# Altium schematic sheet jsk_mbzirc_micro1.SchDoc(jsk_mbzirc_micro1)
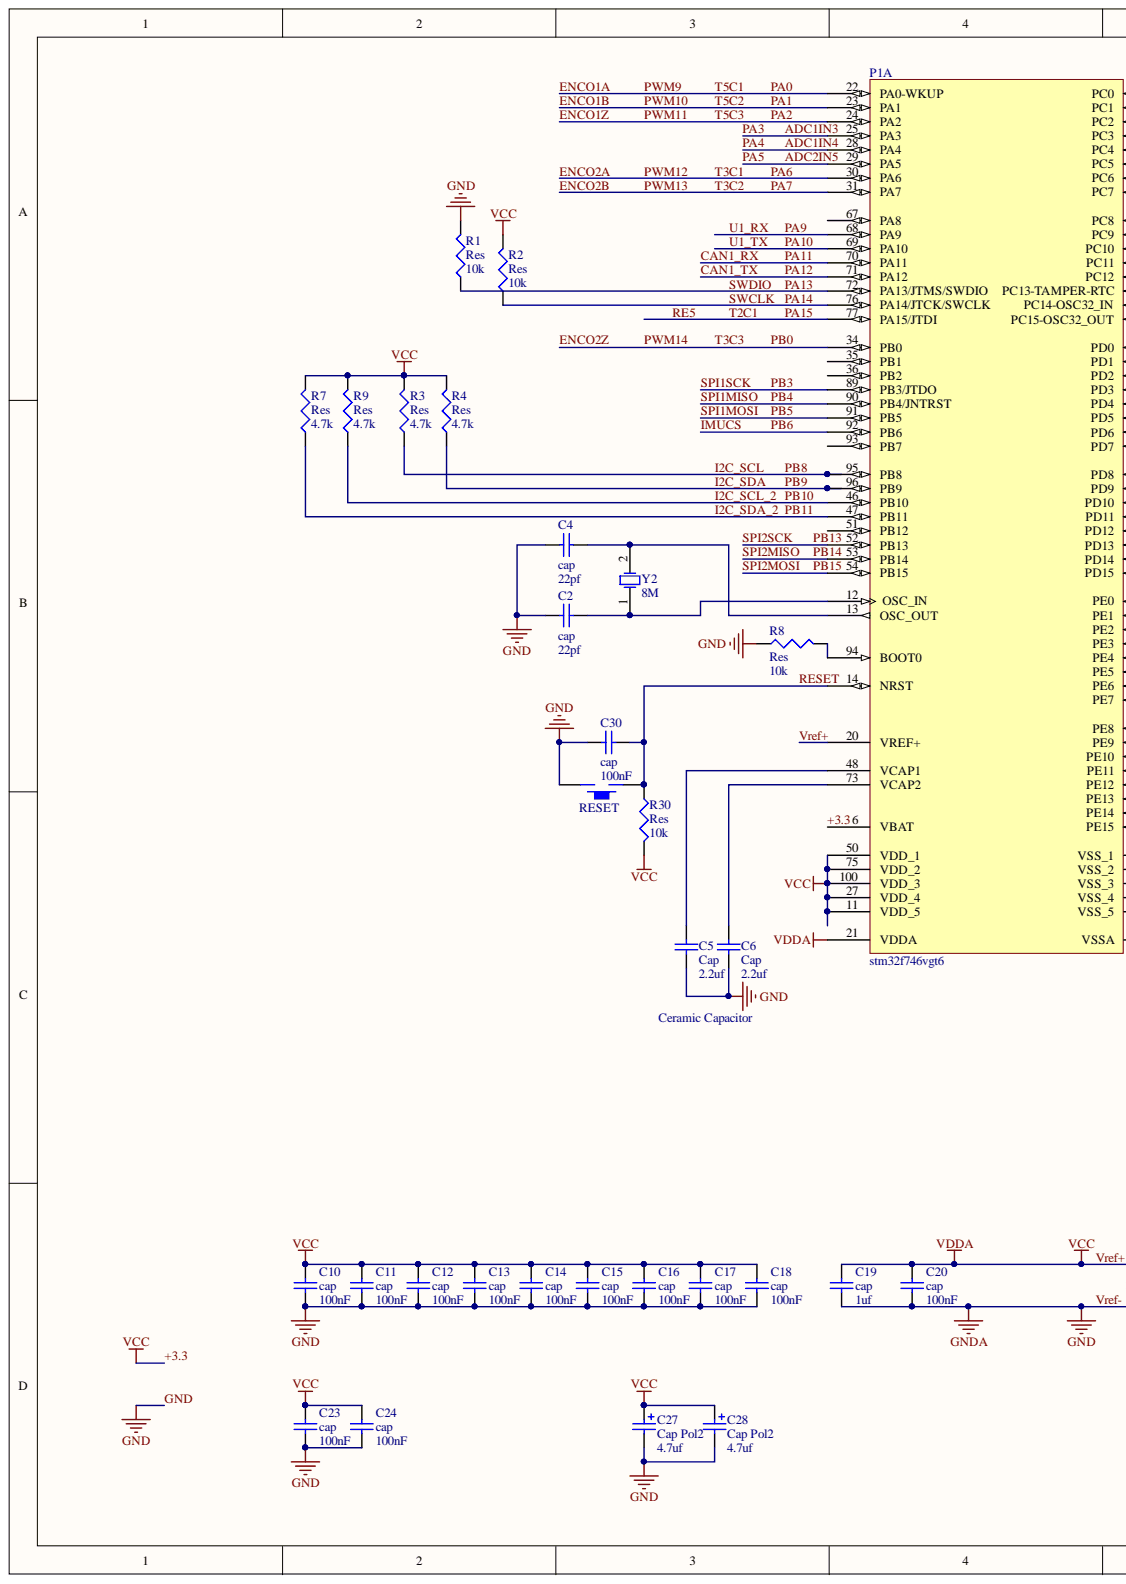
[diagram p0: jsk_mbzirc_micro1.SchDoc, left]
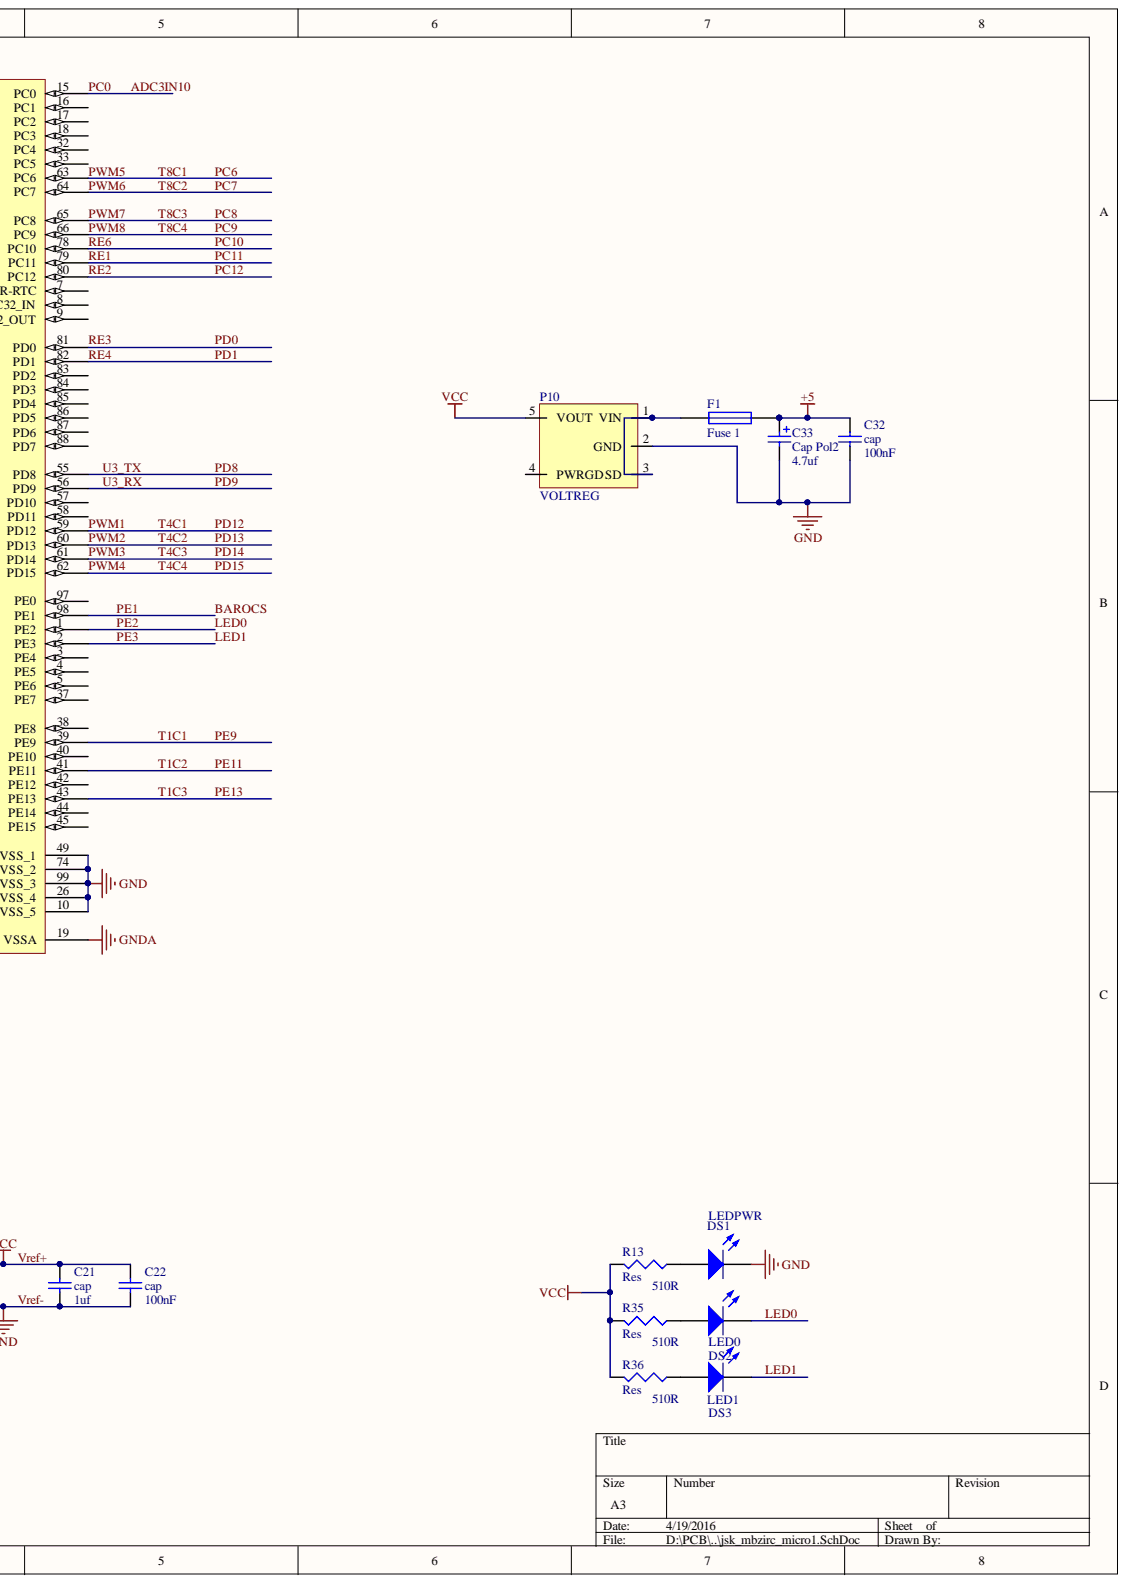
[diagram p1: jsk_mbzirc_micro1.SchDoc, right]

## Parts
C2  cap  value 22pf  [J1-0603]
C4  cap  value 22pf  [J1-0603]
C5  Cap  value 2.2uf  [0805]
C6  Cap  value 2.2uf  [0805]
C10  cap  value 100nF  [J1-0603]
C11  cap  value 100nF  [J1-0603]
C12  cap  value 100nF  [J1-0603]
C13  cap  value 100nF  [J1-0603]
C14  cap  value 100nF  [J1-0603]
C15  cap  value 100nF  [J1-0603]
C16  cap  value 100nF  [J1-0603]
C17  cap  value 100nF  [J1-0603]
C18  cap  value 100nF  [J1-0603]
C19  cap  value 1uf  [J1-0603]
C20  cap  value 100nF  [J1-0603]
C21  cap  value 1uf  [J1-0603]
C22  cap  value 100nF  [J1-0603]
C23  cap  value 100nF  [J1-0603]
C24  cap  value 100nF  [J1-0603]
C27  Cap Pol2  value 4.7uf  [C1206]
C28  Cap Pol2  value 4.7uf  [C1206]
C30  cap  value 100nF  [J1-0603]
C32  cap  value 100nF  [J1-0603]
C33  Cap Pol2  value 4.7uf  [C1206]
DS1  LEDPWR  [1206]
DS2  LED0  [SMDLED]
DS3  LED1  [SMDLED]
F1  Fuse 1  [J1-0603]
P1A  stm32f746vgt6
P10  VOLTREG  [SOT-23]
R1  Res  value 10k  [J1-0603]
R2  Res  value 10k  [J1-0603]
R3  Res  value 4.7k  [J1-0603]
R4  Res  value 4.7k  [J1-0603]
R7  Res  value 4.7k  [J1-0603]
R8  Res  value 10k  [J1-0603]
R9  Res  value 4.7k  [J1-0603]
R13  Res  value 510R  [J1-0603]
R30  Res  value 10k  [J1-0603]
R35  Res  value 510R  [J1-0603]
R36  Res  value 510R  [J1-0603]
RESET  KEY_M  [TSWITCH]  (value not drawn)
Y2  8M  [XTALSMD8]

## Nets
+5: C32.2 C33.1 F1.2
+3.3: C10.2 C11.2 C12.2 C13.2 C14.2 C15.2 C16.2 C17.2 C18.2 C19.2 C20.2 C21.2 C22.2 C23.2 C24.2 C27.1 C28.1 P1A.6(VBAT) P1A.11(VDD_5) P1A.20(VREF+) P1A.21(VDDA) P1A.27(VDD_4) P1A.50(VDD_1) P1A.75(VDD_2) P1A.100(VDD_3) P10.5(VOUT) R2.2 R3.2 R4.2 R7.2 R9.2 R13.2 R30.2 R35.2 R36.2
ADC1IN3: P1A.25(PA3)
ADC1IN4: P1A.28(PA4)
ADC2IN5: P1A.29(PA5)
ADC3IN10: P1A.15(PC0)
BAROCS: P1A.98(PE1)
CAN1_RX: P1A.70(PA11)
CAN1_TX: P1A.71(PA12)
ENCO1A: P1A.22(PA0-WKUP)
ENCO1B: P1A.23(PA1)
ENCO1Z: P1A.24(PA2)
ENCO2A: P1A.30(PA6)
ENCO2B: P1A.31(PA7)
ENCO2Z: P1A.34(PB0)
GND: C2.1 C4.1 C5.1 C6.1 C10.1 C11.1 C12.1 C13.1 C14.1 C15.1 C16.1 C17.1 C18.1 C19.1 C20.1 C21.1 C22.1 C23.1 C24.1 C27.2 C28.2 C30.1 C32.1 C33.2 DS1.2 P1A.10(VSS_5) P1A.19(VSSA) P1A.26(VSS_4) P1A.49(VSS_1) P1A.74(VSS_2) P1A.99(VSS_3) P10.2(GND) R1.2 R8.1 RESET.2
I2C_SCL: P1A.95(PB8) R3.1
I2C_SCL_2: P1A.46(PB10) R9.1
I2C_SDA: P1A.96(PB9) R4.1
I2C_SDA_2: P1A.47(PB11) R7.1
IMUCS: P1A.92(PB6)
LED0: DS2.2 P1A.1(PE2)
LED1: DS3.2 P1A.2(PE3)
PA9: P1A.68(PA9)
PA10: P1A.69(PA10)
PA13: P1A.72(PA13/JTMS/SWDIO) R1.1
PA14: P1A.76(PA14/JTCK/SWCLK) R2.1
PA15: P1A.77(PA15/JTDI)
PB3: P1A.89(PB3/JTDO)
PB4: P1A.90(PB4/JNTRST)
PB5: P1A.91(PB5)
PB13: P1A.52(PB13)
PB14: P1A.53(PB14)
PB15: P1A.54(PB15)
PC6: P1A.63(PC6)
PC7: P1A.64(PC7)
PC8: P1A.65(PC8)
PC9: P1A.66(PC9)
PC10: P1A.78(PC10)
PC11: P1A.79(PC11)
PC12: P1A.80(PC12)
PD0: P1A.81(PD0)
PD1: P1A.82(PD1)
PD8: P1A.55(PD8)
PD9: P1A.56(PD9)
PD12: P1A.59(PD12)
PD13: P1A.60(PD13)
PD14: P1A.61(PD14)
PD15: P1A.62(PD15)
PE9: P1A.39(PE9)
PE11: P1A.41(PE11)
PE13: P1A.43(PE13)
RESET: C30.2 P1A.14(NRST) R30.1 RESET.1
NetC2_2: C2.2 P1A.12(OSC_IN) Y2.1
NetC4_2: C4.2 P1A.13(OSC_OUT) Y2.2
NetC5_2: C5.2 P1A.48(VCAP1)
NetC6_2: C6.2 P1A.73(VCAP2)
NetDS1_1: DS1.1 R13.1
NetDS2_1: DS2.1 R35.1
NetDS3_1: DS3.1 R36.1
NetF1_1: F1.1 P10.1(VIN) P10.3(SD)
NetP1A_94: P1A.94(BOOT0) R8.2

Not connected: P1A 3(PE4) 4(PE5) 5(PE6) 7(PC13-TAMPER-RTC) 8(PC14-OSC32_IN) 9(PC15-OSC32_OUT) 16(PC1) 17(PC2) 18(PC3) 32(PC4) 33(PC5) 35(PB1) 36(PB2) 37(PE7) 38(PE8) 40(PE10) 42(PE12) 44(PE14) 45(PE15) 51(PB12) 57(PD10) 58(PD11) 67(PA8) 83(PD2) 84(PD3) 85(PD4) 86(PD5) 87(PD6) 88(PD7) 93(PB7) 97(PE0); P10 4(PWRGD)

## Library data of the parts
C2 C10-C24 C32 = Cap Semi: Capacitor (Semiconductor SIM Model); Component Kind: Standard; LatestRevisionDate: 29-May-2009; LatestRevisionNote: IPC-7351 Footprint Added.; Library Name: Miscellaneous Devices.IntLib; PackageDescription: Chip Capacitor; PackageReference: C1206; Published: 8-Jun-2000; Signal Integrity: Cap Semi; Simulation: CAP; Publisher: Altium Limited
C4 = Cap Semi: Capacitor (Semiconductor SIM Model); Value: 22pf; Component Kind: Standard; LatestRevisionDate: 29-May-2009; LatestRevisionNote: IPC-7351 Footprint Added.; Library Name: Miscellaneous Devices.IntLib; PackageDescription: Chip Capacitor; PackageReference: C1206; Published: 8-Jun-2000; Signal Integrity: Cap Semi; Simulation: CAP; Publisher: Altium Limited
C5 C6 = Cap: Capacitor; Component Kind: Standard; LatestRevisionDate: 17-Jul-2002; LatestRevisionNote: Re-released for DXP Platform.; Library Name: Miscellaneous Devices.IntLib; PackageDescription: Radial Cap, Thru-Hole; 2 Leads; 0.3 in Pin Spacing; PackageReference: RAD-0.3; Published: 8-Jun-2000; Signal Integrity: Cap; Simulation: CAP; Publisher: Altium Limited
C27 C28 C33 = Cap Pol2: Polarized Capacitor (Axial); Component Kind: Standard; LatestRevisionDate: 17-Jul-2002; LatestRevisionNote: Re-released for DXP Platform.; Library Name: Miscellaneous Devices.IntLib; PackageDescription: Polarized Capacitor; 2 Leads; PackageReference: POLAR0.8; Published: 8-Jun-2000; Signal Integrity: Cap Pol; Simulation: CAP; Publisher: Altium Limited
C30 = Cap Semi: Capacitor (Semiconductor SIM Model); Value: 100nF; Component Kind: Standard; LatestRevisionDate: 29-May-2009; LatestRevisionNote: IPC-7351 Footprint Added.; Library Name: Miscellaneous Devices.IntLib; PackageDescription: Chip Capacitor; PackageReference: C1206; Published: 8-Jun-2000; Signal Integrity: Cap Semi; Simulation: CAP; Publisher: Altium Limited
DS1-DS3 = LED2: Typical RED, GREEN, YELLOW, AMBER GaAs LED; Component Kind: Standard; LatestRevisionDate: 17-Jul-2002; LatestRevisionNote: Re-released for DXP Platform.; PackageDocument: Feb-2002; PackageReference: 3.2X1.6X1.1; Published: 8-Jun-2000; Simulation: LED2; Library Name: 2014ﾄ鷭STM32F4 Exploerｿｪｷ｢ｰ・V1.4_ｽﾌｳﾌﾂﾒｸﾄｰ觸EXPLORER.SCHLIB; Publisher: Altium Limited
F1 = Fuse 1: Fuse; Component Kind: Standard; LatestRevisionDate: 17-Jul-2002; LatestRevisionNote: Re-released for DXP Platform.; Library Name: Miscellaneous Devices.IntLib; PackageDescription: Thru-Hole; 2 Leads; PackageReference: PIN-W2/E2.8; Published: 8-Jun-2000; Simulation: FUSE; Publisher: Altium Limited
P1A = stm32f756vgt6: Component Kind: Standard; Library Name: jskschemlib.SCHLIB
R1-R4 R7-R9 R30 = Res3: Resistor; Component Kind: Standard; LatestRevisionDate: 29-May-2009; LatestRevisionNote: IPC-7351 Footprint Added.; Library Name: Miscellaneous Devices.IntLib; PackageDescription: Chip Resistor; PackageReference: J1-0603; Published: 8-Jun-2000; Signal Integrity: Res; Simulation: RESISTOR; Publisher: Altium Limited
R13 R35 R36 = Res3: Resistor; Value: 510R; Component Kind: Standard; LatestRevisionDate: 29-May-2009; LatestRevisionNote: IPC-7351 Footprint Added.; Library Name: Miscellaneous Devices.IntLib; PackageDescription: Chip Resistor; PackageReference: J1-0603; Published: 8-Jun-2000; Signal Integrity: Res; Simulation: RESISTOR; Publisher: Altium Limited
Y2 = XTAL: Crystal Oscillator; Component Kind: Standard; LatestRevisionDate: 17-Jul-2002; LatestRevisionNote: Re-released for DXP Platform.; Library Name: Miscellaneous Devices.IntLib; PackageDescription: Crystal, Thru-Hole; 2 Leads; Body 3.1 x 8.2 mm (Dia.xH); PackageReference: R38; Published: 8-Jun-2000; Simulation: XTAL; Publisher: Altium Limited
P10 = VOLTREG: Component Kind: Standard; Library Name: jskschemlib.SCHLIB
RESET = KEY_M: Component Kind: Standard; Library Name: 2014ﾄ鷭STM32F4 Exploerｿｪｷ｢ｰ・V1.4_ｽﾌｳﾌﾂﾒｸﾄｰ觸EXPLORER.SCHLIB
